# Altium schematic sheet mpc56_mcupower.SchDoc(mpc56_mcupower)
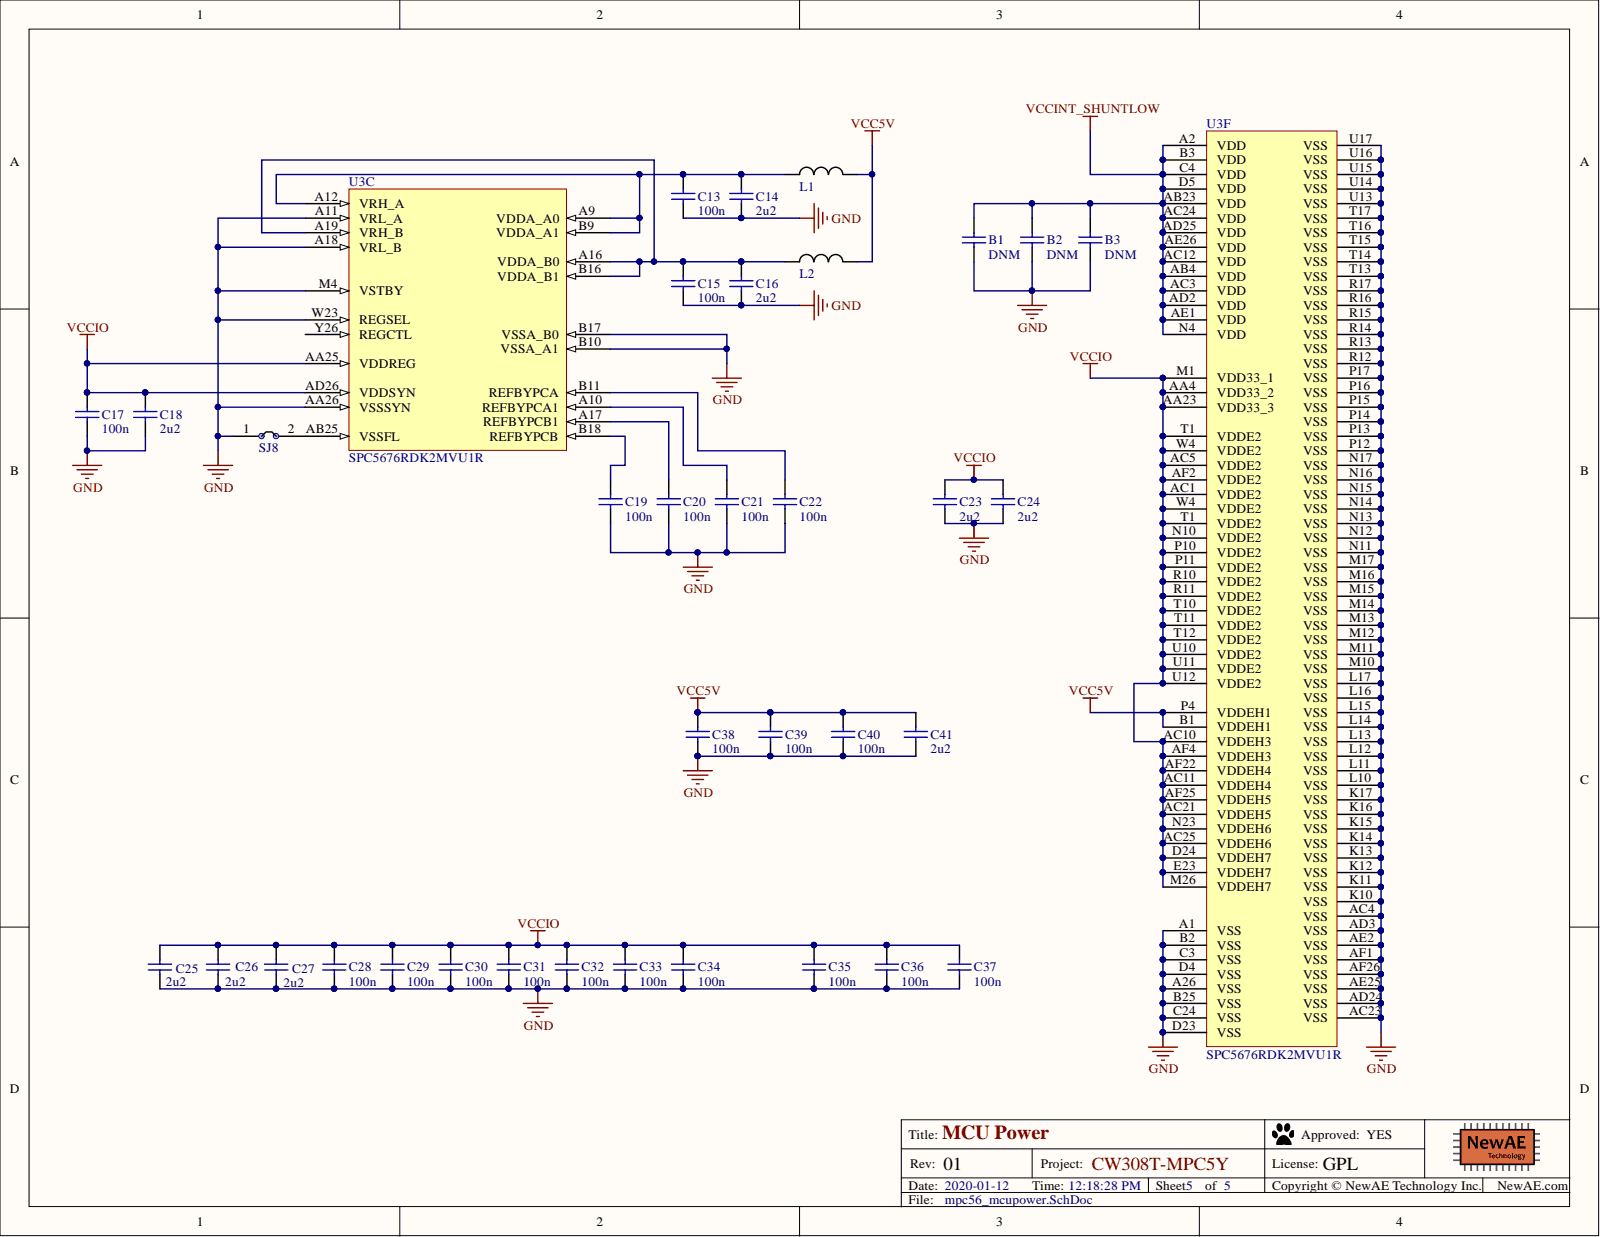
[diagram p0: mpc56_mcupower.SchDoc]

## Parts
B1  DNM  [0402]
B2  DNM  [0402]
B3  DNM  [0402]
C13  100n  [0402]
C14  2u2  [0603]
C15  100n  [0402]
C16  2u2  [0603]
C17  100n  [0402]
C18  2u2  [0603]
C19  100n  [0402]
C20  100n  [0402]
C21  100n  [0402]
C22  100n  [0402]
C23  2u2  [0603]
C24  2u2  [0603]
C25  2u2  [0603]
C26  2u2  [0603]
C27  2u2  [0603]
C28  100n  [0402]
C29  100n  [0402]
C30  100n  [0402]
C31  100n  [0402]
C32  100n  [0402]
C33  100n  [0402]
C34  100n  [0402]
C35  100n  [0402]
C36  100n  [0402]
C37  100n  [0402]
C38  100n  [0402]
C39  100n  [0402]
C40  100n  [0402]
C41  2u2  [0603]
L1  1k @ 1Mhz  [0603]  (value not drawn)
L2  1k @ 1Mhz  [0603]  (value not drawn)
SJ8  NC  [SJ_2S_NC]  (value not drawn)  (no BOM)
U3  SPC5676RDK2MVU1R  [TEPBGA416_27x27]  (parts C,F)

## Nets
GND: B1.2 B2.2 B3.2 C13.2 C14.2 C15.2 C16.2 C17.2 C18.2 C19.2 C20.2 C21.2 C22.2 C23.2 C24.2 C25.2 C26.2 C27.2 C28.2 C29.2 C30.2 C31.2 C32.2 C33.2 C34.2 C35.2 C36.2 C37.2 C38.2 C39.2 C40.2 C41.2 SJ8.1 U3.A1(VSS) U3.A11(VRL_A) U3.A18(VRL_B) U3.A26(VSS) U3.AA26(VSSSYN) U3.AC4(VSS) U3.AC23(VSS) U3.AD3(VSS) U3.AD24(VSS) U3.AE2(VSS) U3.AE25(VSS) U3.AF1(VSS) U3.AF26(VSS) U3.B2(VSS) U3.B10(VSSA_A1) U3.B17(VSSA_B0) U3.B25(VSS) U3.C3(VSS) U3.C24(VSS) U3.D4(VSS) U3.D23(VSS) U3.K10(VSS) U3.K11(VSS) U3.K12(VSS) U3.K13(VSS) U3.K14(VSS) U3.K15(VSS) U3.K16(VSS) U3.K17(VSS) U3.L10(VSS) U3.L11(VSS) U3.L12(VSS) U3.L13(VSS) U3.L14(VSS) U3.L15(VSS) U3.L16(VSS) U3.L17(VSS) U3.M4(VSTBY) U3.M10(VSS) U3.M11(VSS) U3.M12(VSS) U3.M13(VSS) U3.M14(VSS) U3.M15(VSS) U3.M16(VSS) U3.M17(VSS) U3.N11(VSS) U3.N12(VSS) U3.N13(VSS) U3.N14(VSS) U3.N15(VSS) U3.N16(VSS) U3.N17(VSS) U3.P12(VSS) U3.P13(VSS) U3.P14(VSS) U3.P15(VSS) U3.P16(VSS) U3.P17(VSS) U3.R12(VSS) U3.R13(VSS) U3.R14(VSS) U3.R15(VSS) U3.R16(VSS) U3.R17(VSS) U3.T13(VSS) U3.T14(VSS) U3.T15(VSS) U3.T16(VSS) U3.T17(VSS) U3.U13(VSS) U3.U14(VSS) U3.U15(VSS) U3.U16(VSS) U3.U17(VSS) U3.W23(REGSEL)
VCC5V: C38.1 C39.1 C40.1 C41.1 L1.2 L2.2 U3.B1(VDDEH1) U3.P4(VDDEH1)
VCCINT_SHUNTLOW: B1.1 B2.1 B3.1 U3.A2(VDD) U3.AB4(VDD) U3.AB23(VDD) U3.AC3(VDD) U3.AC12(VDD) U3.AC24(VDD) U3.AD2(VDD) U3.AD25(VDD) U3.AE1(VDD) U3.AE26(VDD) U3.B3(VDD) U3.C4(VDD) U3.D5(VDD) U3.N4(VDD)
VCCIO: C17.1 C18.1 C23.1 C24.1 C25.1 C26.1 C27.1 C28.1 C29.1 C30.1 C31.1 C32.1 C33.1 C34.1 C35.1 C36.1 C37.1 U3.AA4(VDD33_2) U3.AA23(VDD33_3) U3.AA25(VDDREG) U3.AC1(VDDE2) U3.AC5(VDDE2) U3.AC10(VDDEH3) U3.AC11(VDDEH4) U3.AC21(VDDEH5) U3.AC25(VDDEH6) U3.AD26(VDDSYN) U3.AF2(VDDE2) U3.AF4(VDDEH3) U3.AF22(VDDEH4) U3.AF25(VDDEH5) U3.D24(VDDEH7) U3.E23(VDDEH7) U3.M1(VDD33_1) U3.M26(VDDEH7) U3.N10(VDDE2) U3.N23(VDDEH6) U3.P10(VDDE2) U3.P11(VDDE2) U3.R10(VDDE2) U3.R11(VDDE2) U3.T1(VDDE2) U3.T10(VDDE2) U3.T11(VDDE2) U3.T12(VDDE2) U3.U10(VDDE2) U3.U11(VDDE2) U3.U12(VDDE2) U3.W4(VDDE2)
NetC13_1: C13.1 C14.1 L1.1 U3.A9(VDDA_A0) U3.A12(VRH_A) U3.B9(VDDA_A1)
NetC15_1: C15.1 C16.1 L2.1 U3.A16(VDDA_B0) U3.A19(VRH_B) U3.B16(VDDA_B1)
NetC19_1: C19.1 U3.B18(REFBYPCB)
NetC20_1: C20.1 U3.A17(REFBYPCB1)
NetC21_1: C21.1 U3.A10(REFBYPCA1)
NetC22_1: C22.1 U3.B11(REFBYPCA)
NetSJ8_2: SJ8.2 U3.AB25(VSSFL)

Not connected: U3 Y26(REGCTL)

## Library data of the parts
B1-B3 = CCHIP-DNM-0402: DNM, 0402; Database Table Name: main; Component Group: Capacitor; Value: DNM; Pin Count: 2; Part Number: CCHIP-DNM-0402; Mounting Technology: SMT; Library Name: NewAE_AltiumLib.DBLib; Component Type: Standard; Component Kind: Standard; Case-EIA: 0402
C13 C15 C17 C19-C22 C28-C40 = CCHIP-100n-0402: 100n, 0402; Database Table Name: main; Value: 100n; Pin Count: 2; Part Number: CCHIP-100n-0402; Mounting Technology: SMT; Library Name: NewAE_AltiumLib.DBLib; Component Type: Standard; Component Kind: Standard; Case-EIA: 0402
C14 C16 C18 C23 C24 C41 = CCHIP-2u2-0603: 2u2, 0603; Database Table Name: main; Case-Metric: 1608; Value: 2u2; Pin Count: 2; Part Number: CCHIP-2u2-0603; Mounting Technology: SMT; Library Name: NewAE_AltiumLib.DBLib; Component Type: Standard; Component Kind: Standard; Case-EIA: 0603
C25-C27 = CCHIP-2u2-0603: 2u2, 0603; Manufacture Part Number 1: CL10B225KP8NNNC; Manufacture 1: Samsung; Rated Voltage: 10VDC; Database Table Name: main; Component Group: Capacitor; Case-Metric: 1608; Value: 2u2; Supplier Part Number 1: 1276-1134-2-ND; Supplier 1: Digi-Key; Pin Count: 2; Part Number: CCHIP-2u2-0603; Mounting Technology: SMT; Library Name: NewAE_AltiumLib.DBLib; Component Type: Standard; Component Kind: Standard; Case-EIA: 0603
L1 L2 = FBCHIP-1k-0603: Ferrite Beads HI CUR CHIP BD 0603 1000OHMS 25%; Manufacture Part Number 1: FBMH1608HM102-T FBMH1608HM102-T FBMH1608HM102-T FBMH1608HM102-T; Manufacture 1: Taiyo Yuden; PP Rotation: 0; Database Table Name: main; Component Group: Inductor; Supplier Part Number 1: 963-FBMH1608HM102-T; Supplier 1: Mouser; RoHS: Yes; PP Material Stack: 0; Pin Count: 2; Part Number: FBCHIP-1k-0603; Mounting Technology: SMT; Library Name: NewAE_AltiumLib.DBLib; Component Type: Standard; Component Kind: Standard
SJ8 = SJ_2S: Solder Jumper, NC; Database Table Name: main; Pin Count: 2; Part Number: SJ_2S; Library Name: NewAE_AltiumLib.DBLib; Component Type: Standard (No BOM)
U3 = SPC5676RDK2MVU1R: Dual-Core PPC, Automotive; Manufacture 1: NXP; PP Rotation: 0; Database Table Name: main; Component Group: Digital IC; Supplier 1: Mouser; RoHS: Yes; PP Material Stack: 0; Pin Count: 412; Part Number: SPC5676RDK2MVU1R; Mounting Technology: SMT; Manufacture Part Number 1: SPC5676RDK2MVU1R; Library Name: NewAE_AltiumLib.DBLib; Component Type: Standard; Component Kind: Standard
